# Altium schematic sheet PlacaSensor_MPXV7002.SchDoc
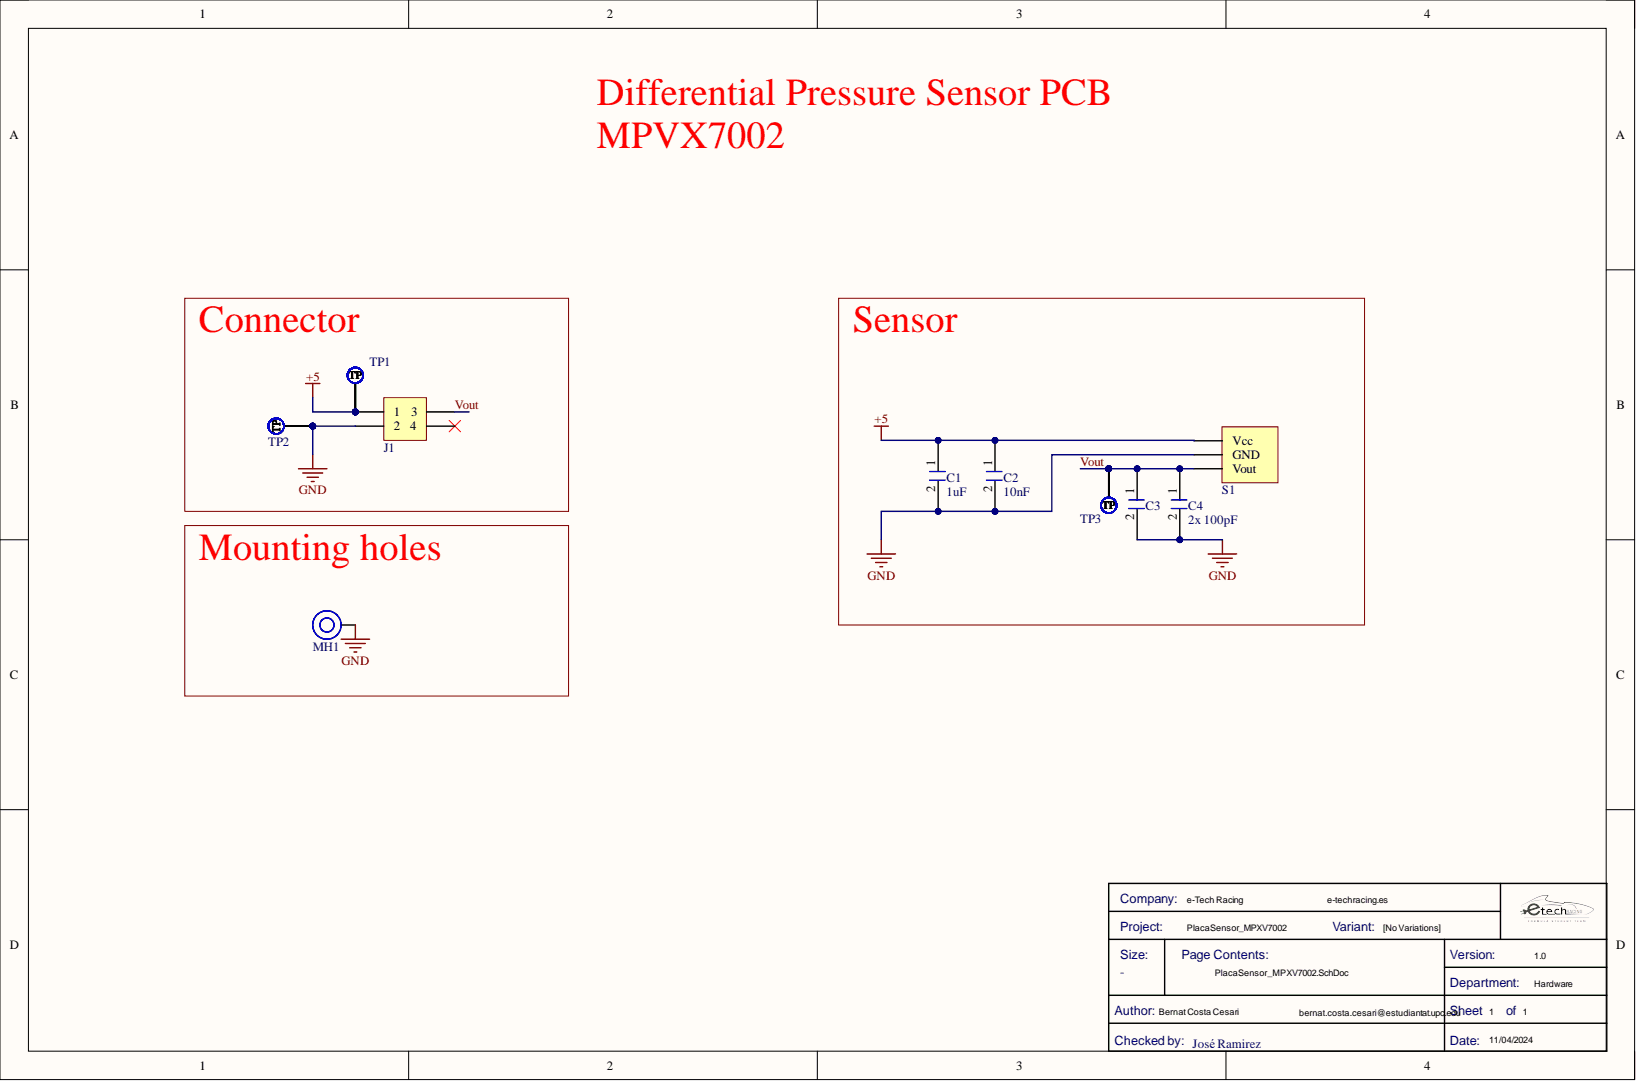
[diagram p0: PlacaSensor_MPXV7002.SchDoc]

## Parts
C1  Capacitance: 1uF  [C0805FNPC-R]  (hidden comment: 885012207103)
C2  Capacitance: 10nF  [C0805FNPC-R]  (hidden comment: 885012207092)
C3  885012007057  [C0805FNPC-W]  (value not drawn)
C4  Capacitance: 2x 100pF  [C0805FNPC-W]  (hidden comment: 885012007057)
J1  J_NanoFit_2x2  [J_NanoFit_2x2_v]  (value not drawn)
MH1  Mounting_Hole_M3  [Mounting_Hole_M3]  (value not drawn)
S1  MPXV7002  [XDCR_MPXV7002DP]
TP1  TestPoint  [TestPoint]  (value not drawn)  (no BOM)
TP2  Test Point  [TESTPOINT_WIDE]  (value not drawn)
TP3  TestPoint  [TestPoint]  (value not drawn)  (no BOM)

## Nets
+5: C1.1 C2.1 J1.1 S1.2 TP1.1
GND: C1.2 C2.2 C3.2 C4.2 J1.2 MH1.1 S1.3 TP2.1
Vout: C3.1 C4.1 J1.3 S1.4 TP3.1

Not connected: J1 4

## Library data of the parts
C1 = C1u-50V-0805FNPC-X7R: Multilayer Ceramic Capacitor, Wcap-Csgp Series, 1 F, 10%, X7R, 50 V, 0805 [2012 Metric] Rohs Compliant: Yes; Manufacturer 1: Wurth Electronics; .Creator: GP; Voltage Rating: 50V; Case Code (Imperial): 0805; Case Code (Metric): 2012; Case/Package: 0805; Category: Ceramic Capacitors; Component Kind: Standard; ComponentLink1Description: http://www.farnell.com/datasheets/1958501.pdf?src-supplier=Farnell; ComponentLink1URL: http://www.farnell.com/datasheets/1958501.pdf?src-supplier=Farnell; ComponentLink2Description: http://www.farnell.com/datasheets/1958501.pdf?src-supplier=Element14; ComponentLink2URL: http://www.farnell.com/datasheets/1958501.pdf?src-supplier=Element14; ComponentLink3Description: https://katalog.we-online.de/pbs/datasheet/885012107003.pdf?src-supplier=Digi-Key; ComponentLink3URL: https://katalog.we-online.de/pbs/datasheet/885012107003.pdf?src-supplier=Digi-Key; ComponentLink4Description: http://www.farnell.com/datasheets/1958501.pdf?src-supplier=Newark; ComponentLink4URL: http://www.farnell.com/datasheets/1958501.pdf?src-supplier=Newark; Dielectric: X7R; Length: 0.079inch; Library Name: Passives.SchLib; Manufacturer Part Number 1: 885012207103; Max Operating Temperature: 85°C; Min Operating Temperature: -55°C; Mount: Surface Mount; Packaging: Cut Tape; Pricing 1: 50=0,27200, 250=0,25300, 500=0,22800, 1250=0,20100, 2500=0,17100 (GBP); REACH SVHC: Unknown; RoHS: Yes; RoHS Compliant: Yes; Stock 1: 5100; Supplier 1: RSComponents; Supplier Part Number 1: 1813523; Thickness: 0.053inch; Tolerance: 10%; Voltage Rating (DC): 50V; Width: 0.049inch
C2 = C10n-50V-0805FNPC-X7R: Cap, 0.01ΜF, 50V, 10%, X7R, 0805; Manufacturer 1: Wurth Electronics; .Creator: DR; Case Code (Imperial): 0805; Case Code (Metric): 2012; Case/Package: 0805; Category: Ceramic Capacitors; Component Kind: Standard; ComponentLink1Description: http://www.farnell.com/datasheets/1958497.pdf?src-supplier=Farnell; ComponentLink1URL: http://www.farnell.com/datasheets/1958497.pdf?src-supplier=Farnell; ComponentLink2Description: http://www.farnell.com/datasheets/1958497.pdf?src-supplier=Element14; ComponentLink2URL: http://www.farnell.com/datasheets/1958497.pdf?src-supplier=Element14; ComponentLink3Description: http://www.farnell.com/datasheets/1958497.pdf?src-supplier=Newark; ComponentLink3URL: http://www.farnell.com/datasheets/1958497.pdf?src-supplier=Newark; ComponentLink4Description: https://katalog.we-online.de/pbs/datasheet/885012207092.pdf?src-supplier=Digi-Key; ComponentLink4URL: https://katalog.we-online.de/pbs/datasheet/885012207092.pdf?src-supplier=Digi-Key; Dielectric: X7R; Length: 0.079inch; Library Name: Passives.SchLib; Manufacturer Part Number 1: 885012207092; Max Operating Temperature: 125°C; Min Operating Temperature: -55°C; Mount: Surface Mount; Packaging: Cut Tape; Pricing 1: 4000=0,03200, 12000=0,03100 (USD); REACH SVHC: Unknown; RoHS: Yes; RoHS Compliant: Yes; Stock 1: 1; Supplier 1: RSComponents; Supplier Part Number 1: 1813941; Thickness: 0.035inch; Tolerance: 10%; Voltage Rating: 50V; Voltage Rating (DC): 50V; Width: 0.049inch
C3 = C100p-50V-0805FNPC-C0G/NP0: Multilayer Ceramic Capacitor, Wcap-Csgp Series, 100 Pf, 5%, C0G / Np0, 50 V, 0805 [2012 Metric] Rohs Compliant: Yes; Manufacturer: Wurth Electronics; .Creator: DR; Capacitance: 100pF; Voltage Rating: 50V; Case Code (Imperial): 0805; Case Code (Metric): 2012; Case/Package: 0805; Category: Ceramic Capacitors; Component Kind: Standard; ComponentLink1Description: http://www.farnell.com/datasheets/1958443.pdf?src-supplier=Farnell; ComponentLink1URL: http://www.farnell.com/datasheets/1958443.pdf?src-supplier=Farnell; ComponentLink2Description: https://katalog.we-online.de/pbs/datasheet/885012007057.pdf?src-supplier=Digi-Key; ComponentLink2URL: https://katalog.we-online.de/pbs/datasheet/885012007057.pdf?src-supplier=Digi-Key; ComponentLink3Description: http://www.farnell.com/datasheets/1958443.pdf?src-supplier=Element14; ComponentLink3URL: http://www.farnell.com/datasheets/1958443.pdf?src-supplier=Element14; ComponentLink4Description: http://www.farnell.com/datasheets/1958443.pdf?src-supplier=Newark; ComponentLink4URL: http://www.farnell.com/datasheets/1958443.pdf?src-supplier=Newark; ComponentLink5Description: Where buy it; ComponentLink5URL: https://www.we-online.com/catalog/en/WCAP-CSGP-50VDC#885012007057; Dielectric: C0G; Length: 0.079inch; Library Name: Passives.SchLib; Manufacturer Part Number: 885012007057; Max Operating Temperature: 125°C; Min Operating Temperature: -55°C; Mount: Surface Mount; Packaging: Cut Tape; Pricing 1: 4000=0,03900, 12000=0,03800 (USD); REACH SVHC: Unknown; RoHS: Yes; RoHS Compliant: Yes; Stock 1: 1; Supplier 1: RSComponents; Supplier Part Number 1: 1813930; Thickness: 0.035inch; Tolerance: 5%; Voltage Rating (DC): 50V; Width: 0.049inch
C4 = C100p-50V-0805FNPC-C0G/NP0: Multilayer Ceramic Capacitor, Wcap-Csgp Series, 100 Pf, 5%, C0G / Np0, 50 V, 0805 [2012 Metric] Rohs Compliant: Yes; Manufacturer: Wurth Electronics; .Creator: DR; Voltage Rating: 50V; Case Code (Imperial): 0805; Case Code (Metric): 2012; Case/Package: 0805; Category: Ceramic Capacitors; Component Kind: Standard; ComponentLink1Description: http://www.farnell.com/datasheets/1958443.pdf?src-supplier=Farnell; ComponentLink1URL: http://www.farnell.com/datasheets/1958443.pdf?src-supplier=Farnell; ComponentLink2Description: https://katalog.we-online.de/pbs/datasheet/885012007057.pdf?src-supplier=Digi-Key; ComponentLink2URL: https://katalog.we-online.de/pbs/datasheet/885012007057.pdf?src-supplier=Digi-Key; ComponentLink3Description: http://www.farnell.com/datasheets/1958443.pdf?src-supplier=Element14; ComponentLink3URL: http://www.farnell.com/datasheets/1958443.pdf?src-supplier=Element14; ComponentLink4Description: http://www.farnell.com/datasheets/1958443.pdf?src-supplier=Newark; ComponentLink4URL: http://www.farnell.com/datasheets/1958443.pdf?src-supplier=Newark; ComponentLink5Description: Where buy it; ComponentLink5URL: https://www.we-online.com/catalog/en/WCAP-CSGP-50VDC#885012007057; Dielectric: C0G; Length: 0.079inch; Library Name: Passives.SchLib; Manufacturer Part Number: 885012007057; Max Operating Temperature: 125°C; Min Operating Temperature: -55°C; Mount: Surface Mount; Packaging: Cut Tape; Pricing 1: 4000=0,03900, 12000=0,03800 (USD); REACH SVHC: Unknown; RoHS: Yes; RoHS Compliant: Yes; Stock 1: 1; Supplier 1: RSComponents; Supplier Part Number 1: 1813930; Thickness: 0.035inch; Tolerance: 5%; Voltage Rating (DC): 50V; Width: 0.049inch
J1 = J_NanoFit_2x2: Conn Nano-Fit Power HDR 4 POS 2.5mm Solder ST Through Hole 4 Terminal Single Port Tray; Manufacturer 1: Molex; Manufacturer Part Number 1: 1053101104; Category: Uncategorized; Component Kind: Standard; ComponentLink1Description: https://www.molex.com/pdm_docs/ps/PS-105300-100-001.pdf?src-supplier=Digi-Key; ComponentLink1URL: https://www.molex.com/pdm_docs/ps/PS-105300-100-001.pdf?src-supplier=Digi-Key; Library Name: Electromechanical.SchLib; Pricing 1: 1=1,43000, 10=1,18000, 50=0,74900, 100=0,72900, 500=0,71400, 1000=0,70700 (EUR); RoHS: Yes; Stock 1: 255; Supplier 1: Farnell; Supplier Part Number 1: 2820681
TP1 TP3 = TestPoint: Test Point 1x1 mm; .Creator: GP; Category: TestPoint; Library Name: e-Tech_Racing.SchLib; .PCBcheck: GP; .SCHcheck: GP
TP2 = TestPoint_Wide: TestPoint 5x5mm; Component Kind: Standard; Library Name: e-Tech_Racing.SchLib
MH1 = Mounting_Hole_M3: Component Kind: Standard; Library Name: Electromechanical.SchLib
S1 = MPXV7002: Component Kind: Standard; Library Name: Sensors.SchLib
